# Altium schematic sheet MainBoardPower.SchDoc
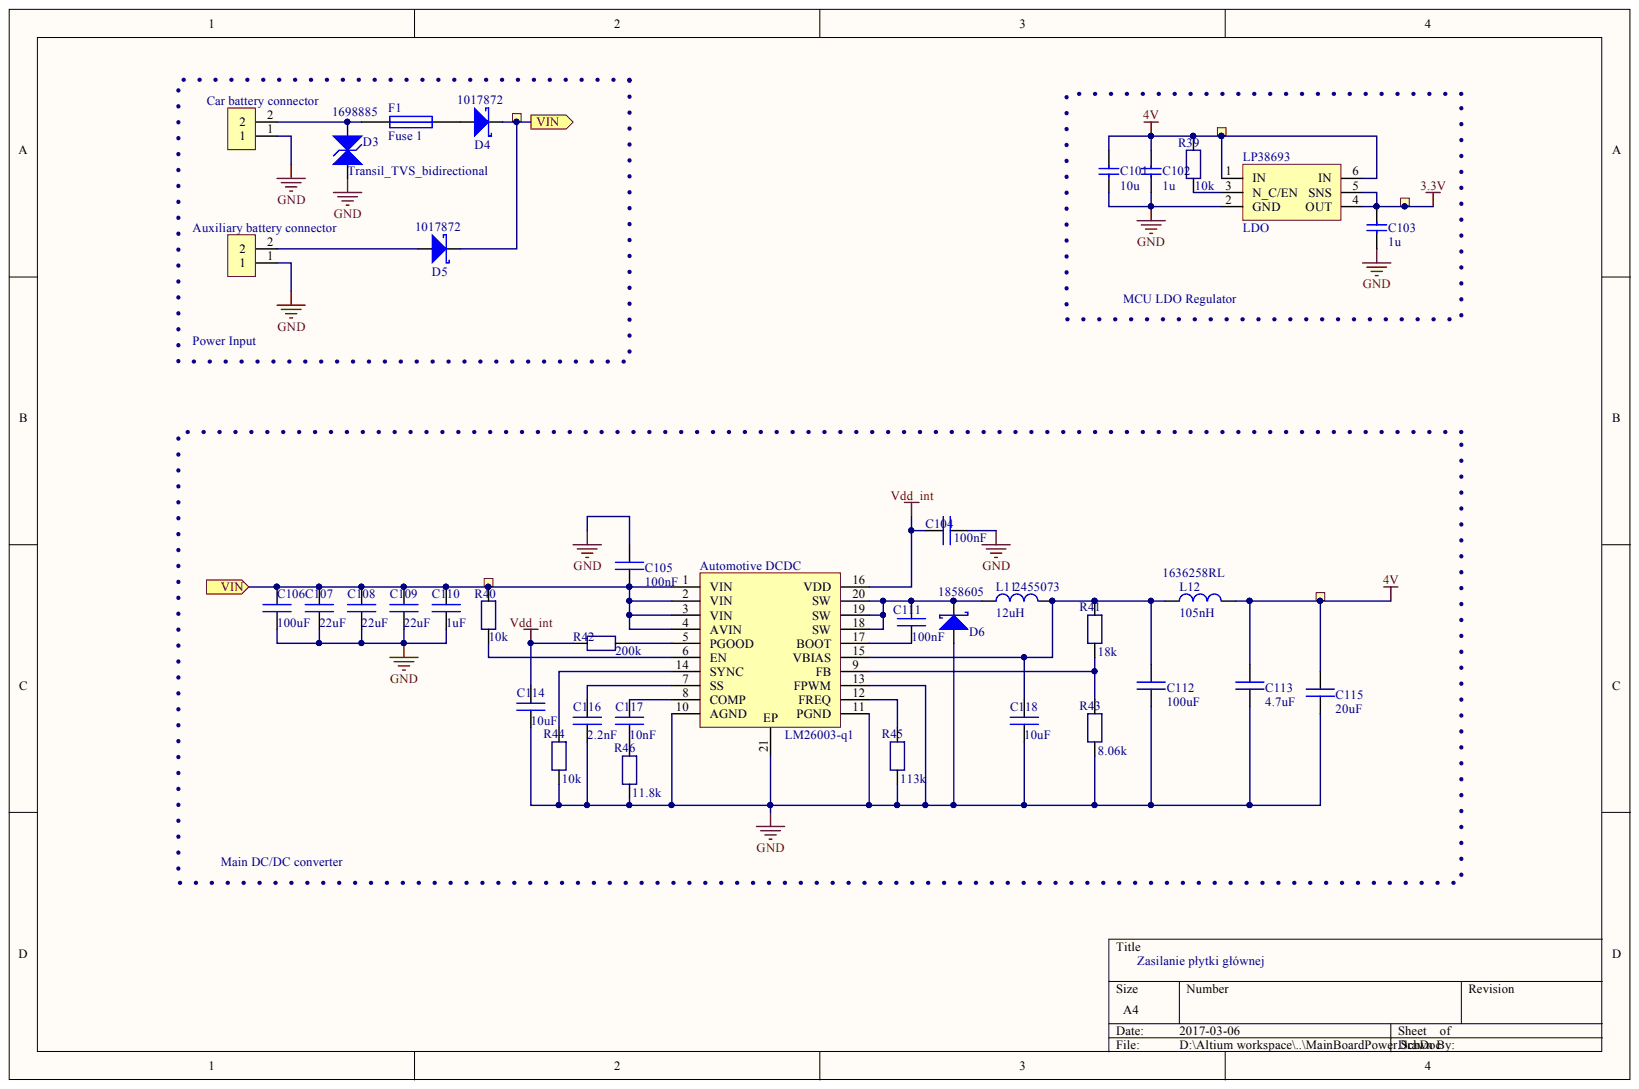
[diagram p0: MainBoardPower.SchDoc]

## Parts
28  Ø1.0mm,SMD  [TP_SMD_Ø1.0mm]  (value not drawn)  (no BOM)
29  Ø1.0mm,SMD  [TP_SMD_Ø1.0mm]  (value not drawn)  (no BOM)
30  Ø1.0mm,SMD  [TP_SMD_Ø1.0mm]  (value not drawn)  (no BOM)
31  Ø1.0mm,SMD  [TP_SMD_Ø1.0mm]  (value not drawn)  (no BOM)
32  Ø1.0mm,SMD  [TP_SMD_Ø1.0mm]  (value not drawn)  (no BOM)
Auxiliary_battery_connector  (no value)  [ARK_3.5mm]
C101  10u  [CAPC1608X06L]
C102  1u  [CAPC1608X06L]
C103  1u  [CAPC1608X06L]
C104  100nF  [0402]
C105  100nF  [0402]
C106  100uF  [1206]
C107  22uF  [0805]
C108  22uF  [0805]
C109  22uF  [0805]
C110  1uF  [0402]
C111  100nF  [0805]
C112  100uF  [1206]
C113  4.7uF  [0603]
C114  10uF  [0805]
C115  20uF  [0805]
C116  2.2nF  [0805]
C117  10nF  [0805]
C118  10uF  [0805]
Car_battery_connector  (no value)  [ARK_3.5mm]
D3  Transil_TVS_bidirectional  Supplier Part Number 1: 1698885  [DO-214-AB]
D4  Supplier Part Number 1: 1017872  [DO-214-AA]  (hidden comment: Shottky_DO-214-AA)
D5  Supplier Part Number 1: 1017872  [DO-214-AA]  (hidden comment: Shottky_DO-214-AA)
D6  Supplier Part Number 1: 1858605  [SMA]  (hidden comment: Shottky)
F1  Fuse 1  [PIN-W2/E2.8]
L11  12uH  Supplier Part Number 1: 2455073  [SRR1210A]
L12  105nH  Supplier Part Number 1: 1636258RL  [7.15mm x 7mm x 5mm]
LM26003-q1  Automotive DCDC  [TSSOP20_footprint]
LP38693  LDO  [WSON-6]
R39  value 10k  [RES_0402]  (hidden comment: RES_0402_1k)
R40  value 10k  [RES_0402]  (hidden comment: RES_0402_1k)
R41  value 18k  [RES_0402]  (hidden comment: RES_0402_1k)
R42  value 200k  [RES_0402]  (hidden comment: RES_0402_1k)
R43  value 8.06k  [RES_0402]  (hidden comment: RES_0402_1k)
R44  value 10k  [RES_0402]  (hidden comment: RES_0402_1k)
R45  value 113k  [RES_0402]  (hidden comment: RES_0402_1k)
R46  value 11.8k  [RES_0402]  (hidden comment: RES_0402_1k)

## Ports
VIN

## Nets
3.3V: 30.1 C103.1 LP38693.4(OUT) LP38693.5(SNS)
4V: 29.1 32.1 C101.1 C102.1 C113.1 C115.2 L12.2 LP38693.1(IN) LP38693.6(IN) R39.2
GND: Auxiliary_battery_connector.1 C101.2 C102.2 C103.2 C104.2 C105.2 C106.1 C107.1 C108.1 C109.1 C110.1 C112.1 C113.2 C114.1 C115.1 C116.1 C118.1 Car_battery_connector.1 D3.1 D6.1 LM26003-q1.10(AGND) LM26003-q1.11(PGND) LM26003-q1.13(FPWM) LM26003-q1.21(EP) LP38693.2(GND) R43.2 R44.2 R45.2 R46.2
VDD_INT: C104.1 C114.2 LM26003-q1.16(VDD) R42.2
VIN: 28.1 31.1 C105.1 C106.2 C107.2 C108.2 C109.2 C110.2 D4.2 D5.2 LM26003-q1.1(VIN) LM26003-q1.2(VIN) LM26003-q1.3(VIN) LM26003-q1.4(AVIN) R40.1 ; port VIN
NetAuxiliary battery connector_2: Auxiliary_battery_connector.2 D5.1
NetC111_1: C111.1 LM26003-q1.17(BOOT)
NetC111_2: C111.2 D6.2 L11.1 LM26003-q1.18(SW) LM26003-q1.19(SW) LM26003-q1.20(SW)
NetC112_2: C112.2 C118.2 L11.2 L12.1 LM26003-q1.15(VBIAS) R41.1
NetC116_2: C116.2 LM26003-q1.7(SS)
NetC117_1: C117.1 R46.1
NetC117_2: C117.2 LM26003-q1.8(COMP)
NetCar battery connector_2: Car_battery_connector.2 D3.2 F1.1
NetD4_1: D4.1 F1.2
NetLM26003-q1_5: LM26003-q1.5(PGOOD) R42.1
NetLM26003-q1_6: LM26003-q1.6(EN) R40.2
NetLM26003-q1_9: LM26003-q1.9(FB) R41.2 R43.1
NetLM26003-q1_12: LM26003-q1.12(FREQ) R45.1
NetLM26003-q1_14: LM26003-q1.14(SYNC) R44.1
NetLP38693_3: LP38693.3(N_C/EN) R39.1

## Library data of the parts
28-32 = TESTPAD: 1.0mm circular SMD testpad; Manufacturer: Nordic Semiconductor; Artikkelnr Noca: N.A.; LatestRevisionDate: 2013-06-11; Library Name: pca20006.IntLib; Part Number: TP_SMD_Ø1.0MM; Pin Count: 1; Published: 2013-06-11; Value: Ø1.0mm,SMD; Publisher: Nordic Semiconductor
Auxiliary_battery_connector Car_battery_connector = Component_1: Component Kind: Standard; Library Name: Terminal_block.SchLib
C101-C103 = C0603-4µ7: Capacitor, X5R, ±10%; Artikkelnr Noca: 20069060; Artikkelnr Simpro: N.A.; Database Table Name: Capacitors$; Note: 6.3V; Value: 4.7µF; Component Kind: Standard; Footprint Doc: 0603; LatestRevisionDate: 2009-11-23; Library Name: Nordic Passive DB.DbLib; Part Number: C0603-4µ7; Pin Count: 2; Published: 2009-05-08; Supplier 1: Farnell; Supplier Part Number 1: 1327684; Publisher: Nordic Semiconductor
C104-C118 = Capacitor_MLCC: ?; Component Kind: Standard; Library Name: Capacitors.SchLib
D3 = TVS_bidirectional: TVS_bi; Component Kind: Standard; Library Name: Diodes.SchLib; Supplier 1: Farnell
D6 = Shottky_diode: Component Kind: Standard; Library Name: Diodes.SchLib; Supplier 1: Farnell
F1 = Fuse 1: Fuse; Component Kind: Standard; LatestRevisionDate: 17-Jul-2002; LatestRevisionNote: Re-released for DXP Platform.; Library Name: Miscellaneous Devices.IntLib; PackageDescription: Thru-Hole; 2 Leads; PackageReference: PIN-W2/E2.8; Published: 8-Jun-2000; Simulation: FUSE; Publisher: Altium Limited
L11 L12 = Inductor: Component Kind: Standard; Library Name: Inductors.SchLib; Supplier 1: Farnell
LM26003-q1 = LM26003-q1: Component Kind: Standard; Library Name: LM26003-Q1.SchLib
LP38693 = LP38693 WSON Package: Component Kind: Standard; Library Name: LP38693_LDOSchLib.SchLib
D4 D5 = Shottky_DO-214-AA: Component Kind: Standard; Library Name: Diodes.SchLib; Supplier 1: Farnell
R39-R46 = RES_0402_1k: Component Kind: Standard; Supplier 1: Digi-Key; Supplier 2: Farnell; Supplier 3: Mouser; Supplier Part Number 1: 311-1.0KJRTR-ND; Supplier Part Number 2: 1358043; Supplier Part Number 3: 603-RC0402FR-071KL; Library Name: ONEMETER.SchLib
